# Altium schematic sheet pca20018 - Codec.SchDoc
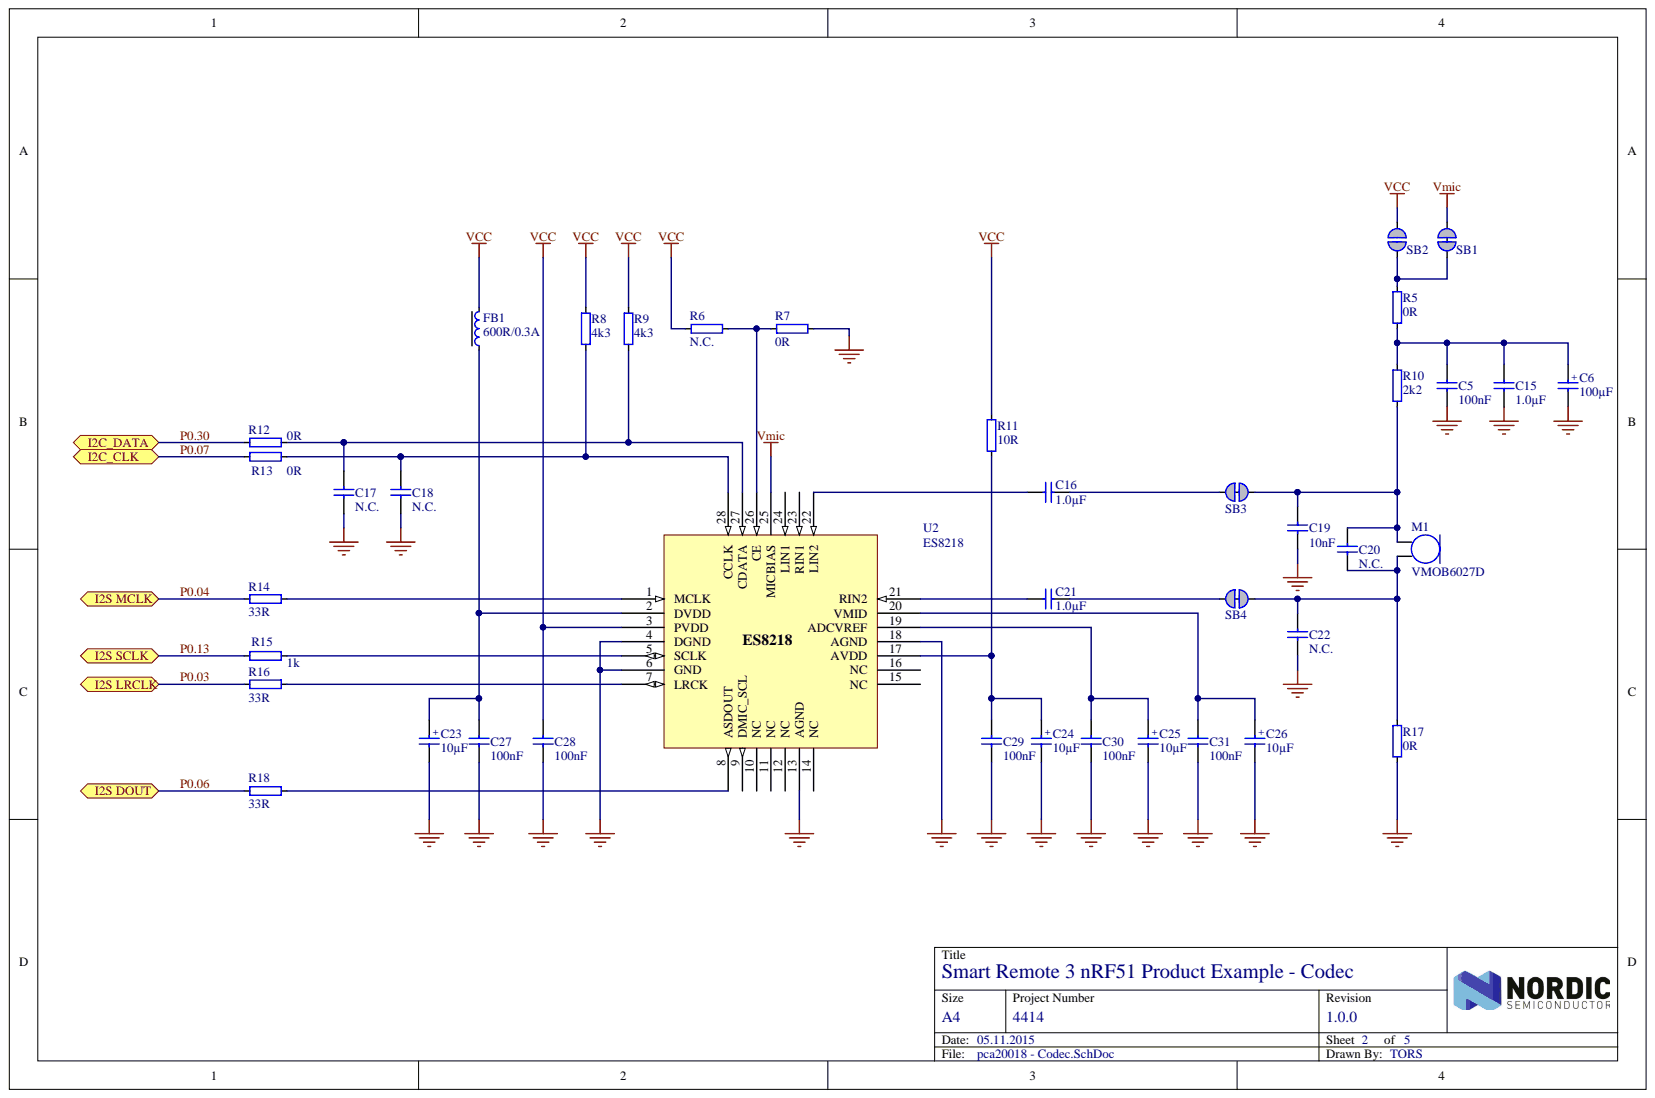
[diagram p0: pca20018 - Codec.SchDoc]

## Parts
C5  100nF  [CAPC1005X04L]
C6  100µF  [CAPMP3528X21N]
C15  1.0µF  [CAPC1005X04L]
C16  1.0µF  [CAPC1005X04L]
C17  N.C.  [CAPC1005X04L]
C18  N.C.  [CAPC1005X04L]
C19  10nF  [CAPC1005X04L]
C20  N.C.  [CAPC1005X04L]
C21  1.0µF  [CAPC1005X04L]
C22  N.C.  [CAPC1005X04L]
C23  10µF  [CAPMP3216X18N]
C24  10µF  [CAPMP3216X18N]
C25  10µF  [CAPMP3216X18N]
C26  10µF  [CAPMP3216X18N]
C27  100nF  [CAPC1005X04L]
C28  100nF  [CAPC1005X04L]
C29  100nF  [CAPC1005X04L]
C30  100nF  [CAPC1005X04L]
C31  100nF  [CAPC1005X04L]
FB1  600R/0.3A  [INDC1005X04L]
M1  VMOB6027D  [MIC_VMOB6027D]
R5  0R  [RESC1005X04L]
R6  N.C.  [RESC1005X04L]
R7  0R  [RESC1005X04L]
R8  4k3  [RESC1005X04L]
R9  4k3  [RESC1005X04L]
R10  2k2  [RESC1005X04L]
R11  10R  [RESC1005X04L]
R12  0R  [RESC1005X04L]
R13  0R  [RESC1005X04L]
R14  33R  [RESC1005X04L]
R15  1k  [RESC1005X04L]
R16  33R  [RESC1005X04L]
R17  0R  [RESC1005X04L]
R18  33R  [RESC1005X04L]
SB1  Solderbridge  [Solderbridge_Shorted]  (value not drawn)
SB2  Solderbridge  [Solderbridge]  (value not drawn)  (no BOM)
SB3  Solderbridge  [Solderbridge_Shorted]  (value not drawn)
SB4  Solderbridge  [Solderbridge_Shorted]  (value not drawn)
U2  ES8218  [QFN45P400X400X90_HS-29N]

## Ports
I2C_CLK, I2C_DATA, I2S DOUT, I2S LRCLK, I2S MCLK, I2S SCLK

## Nets
GND: C5.1 C6.2 C15.1 C17.1 C18.1 C19.1 C22.1 C23.2 C24.2 C25.2 C26.2 C27.1 C28.1 C29.1 C30.1 C31.1 R7.1 R17.1 U2.4(DGND) U2.6(GND) U2.13(AGND) U2.18(AGND) U2.29
VCC: C28.2 FB1.2 R6.2 R8.2 R9.2 R11.2 SB2.1 U2.3(PVDD)
VMIC: SB1.1 U2.25(MICBIAS)
P0.03: R16.1 ; port I2S LRCLK
P0.04: R14.1 ; port I2S MCLK
P0.06: R18.1 ; port I2S DOUT
P0.07: R13.1 ; port I2C_CLK
P0.13: R15.2 ; port I2S SCLK
P0.30: R12.1 ; port I2C_DATA
NetC5_2: C5.2 C6.1 C15.2 R5.1 R10.2
NetC16_1: C16.1 SB3.1
NetC16_2: C16.2 U2.22(LIN2)
NetC17_2: C17.2 R9.1 R12.2 U2.27(CDATA)
NetC18_2: C18.2 R8.1 R13.2 U2.28(CCLK)
NetC19_2: C19.2 C20.2 M1.1 R10.1 SB3.2
NetC20_1: C20.1 C22.2 M1.2 R17.2 SB4.2
NetC21_1: C21.1 SB4.1
NetC21_2: C21.2 U2.21(RIN2)
NetC23_1: C23.1 C27.2 FB1.1 U2.2(DVDD)
NetC24_1: C24.1 C29.2 R11.1 U2.17(AVDD)
NetC25_1: C25.1 C30.2 U2.19(ADCVREF)
NetC26_1: C26.1 C31.2 U2.20(VMID)
NetR5_2: R5.2 SB1.2 SB2.2
NetR6_1: R6.1 R7.2 U2.26(CE)
NetR14_2: R14.2 U2.1(MCLK)
NetR15_1: R15.1 U2.5(SCLK)
NetR16_2: R16.2 U2.7(LRCK)
NetR18_2: R18.2 U2.8(ASDOUT)

Not connected: U2 9(DMIC_SCL) 10(NC) 11(NC) 12(NC) 14(NC) 15(NC) 16(NC) 23(RIN1) 24(LIN1)

## Library data of the parts
C5 C27-C31 = C0402-100n: Capacitor, X7R, ±10%; Artikkelnr Noca: 20039200; Artikkelnr Simpro: N.A.; Database Table Name: Capacitors$; Note: 16V; Component Kind: Standard; Footprint Doc: 0402; LatestRevisionDate: 2009-11-23; Library Name: Nordic Passive DB.DbLib; Part Number: C0402-100n; Pin Count: 2; Published: 2009-05-08; Value: 100nF; Publisher: Nordic Semiconductor
C6 = C3528-100µF: Capacitor, Tantalum, ±10%; Manufacturer: Vishay Sprague; Manufacturer Part Number: TR3B107K6R3C0500; Artikkelnr Noca: N.A.; Database Table Name: Capacitors$; Note: 6.3V; Artikkelnr Simpro: N.A.; Component Kind: Standard; Datasheet: http://www.farnell.com/datasheets/438325.pdf; Footprint Doc: 3528; LatestRevisionDate: 2013-06-05; Library Name: Nordic Passive DB.DbLib; Part Number: C3528-100µF; Pin Count: 2; Published: 2010-10-26; Supplier: Farnell; Supplier part number: 175-4047; Value: 100µF; Publisher: Nordic Semiconductor
C15 C16 C21 = C0402-1µ0: Capacitor, X5R, ±5%; Artikkelnr Noca: 20039235; Artikkelnr Simpro: N.A.; Database Table Name: Capacitors$; Note: 6.3V; Component Kind: Standard; Footprint Doc: 0402; LatestRevisionDate: 2009-11-23; Library Name: Nordic Passive DB.DbLib; Part Number: C0402-1µ0; Pin Count: 2; Published: 2009-05-08; Value: 1.0µF; Publisher: Nordic Semiconductor
C17 C18 C20 C22 = C0402-N.C.: Not mounted; Artikkelnr Noca: N.A.; Database Table Name: Capacitors$; Note: Chip Cap; Artikkelnr Simpro: N.A.; Component Kind: Standard; Footprint Doc: 0402; LatestRevisionDate: 2009-05-08; Library Name: Nordic Passive DB.DbLib; Part Number: C0402-N.C.; Pin Count: 2; Published: 2009-05-08; Value: N.C.; Publisher: Nordic Semiconductor
C19 = C0402-10n: Capacitor, X7R, ±10%; Artikkelnr Noca: 20039166; Artikkelnr Simpro: N.A.; Database Table Name: Capacitors$; Note: 16V; Component Kind: Standard; Footprint Doc: 0402; LatestRevisionDate: 2009-11-23; Library Name: Nordic Passive DB.DbLib; Part Number: C0402-10n; Pin Count: 2; Published: 2009-05-08; Value: 10nF; Publisher: Nordic Semiconductor
C23-C26 = C1206-10µ_Tantal: Capacitor, Tantalum, ±10%; Artikkelnr Noca: N.A.; Database Table Name: Capacitors$; Note: 16V; Artikkelnr Simpro: N.A.; Component Kind: Standard; Footprint Doc: 1206; LatestRevisionDate: 2013-12-17; Library Name: Nordic Passive DB.DbLib; Part Number: C1206-10µ_Tantal; Pin Count: 2; Published: 2011-01-17; Supplier: Digi-Key; Supplier part number: 399-8269-1-ND; Value: 10µF; Publisher: Nordic Semiconductor
FB1 = FB0402-600R@100MHz-300mA: Ferrite Bead, 600 Ohm @ 100MHz, 300mA, 600 mOhm Max; 2nd source part number: 490-1006-1-ND; Manufacturer: Murata; Manufacturer Part Number: BLM15AG601SN1D; Artikkelnr Noca: 33029424; Artikkelnr Simpro: N.A.; Database Table Name: Inductors$; Note: Chip EMIFIL Inductor Type Chip Ferrite Bead; 2nd source Supplier: Digi-Key; Component Kind: Standard; Datasheet: http://www.farnell.com/datasheets/5144.pdf; Footprint Doc: 0402; LatestRevisionDate: 2014-01-10; Library Name: Nordic Passive DB.DbLib; Part Number: FB0402-600R@100MHz-300mA; Pin Count: 2; Published: 2011-09-18; Supplier: Farnell; Supplier part number: 151-5762; Value: 600R/0.3A; Publisher: Nordic Semiconductor
M1 = VMOB6027D-423G-PAHRAC-00-0: Omnidirectional Back Electret Condenser Microphone; Manufacturer: VECO; Artikkelnr Noca: N.A.; Database Table Name: Transducers$; Artikkelnr Simpro: N.A.; Component Kind: Standard; Footprint Doc: MIC_VMOB6027D; LatestRevisionDate: 2014-07-29; Library Name: Nordic Passive DB.DbLib; Manufacturer Part Number: VMOB6027D-423G-PAHRAC-00-0; Part Number: VMOB6027D-423G-PAHRAC-00-0; Pin Count: 2; Published: 2014-07-29; Value: VMOB6027D; Datasheet: C:\Datasheets\VECO\VMOB6027D.pdf; Publisher: Nordic Semiconductor
R5 R7 R12 R13 R17 = R0402-0R: Resistor, ±5%, 0.063W; Artikkelnr Noca: 27049000; Artikkelnr Simpro: N.A.; Database Table Name: Resistors$; Note: Chip Res 5%; Component Kind: Standard; Footprint Doc: 0402; LatestRevisionDate: 2009-11-23; Library Name: Nordic Passive DB.DbLib; Part Number: R0402-0R; Pin Count: 2; Published: 2009-05-08; Supplier: Farnell; Supplier part number: 146-9661; Value: 0R; Publisher: Nordic Semiconductor
R6 = R0402-N.C.: Not mounted; Artikkelnr Noca: N.A.; Database Table Name: Resistors$; Note: Chip Res; Artikkelnr Simpro: N.A.; Component Kind: Standard; Footprint Doc: 0402; LatestRevisionDate: 2009-05-08; Library Name: Nordic Passive DB.DbLib; Part Number: R0402-N.C.; Pin Count: 2; Published: 2009-05-08; Value: N.C.; Publisher: Nordic Semiconductor
R8 R9 = R0402-3k9: Resistor, ±1%, 0.063W; Artikkelnr Simpro: N.A.; Database Table Name: Resistors$; Note: Chip Res 1%; Component Kind: Standard; Footprint Doc: 0402; LatestRevisionDate: 2009-11-23; Library Name: Nordic Passive DB.DbLib; Pin Count: 2; Published: 2009-05-08; Value: 4k3; Publisher: Nordic Semiconductor
R10 = R0402-2k2: Resistor, ±1%, 0.063W; Artikkelnr Noca: 27049081; Artikkelnr Simpro: N.A.; Database Table Name: Resistors$; Note: Chip Res 1%; Component Kind: Standard; Footprint Doc: 0402; LatestRevisionDate: 2009-11-23; Library Name: Nordic Passive DB.DbLib; Part Number: R0402-2k2; Pin Count: 2; Published: 2009-05-08; Supplier: Farnell; Supplier part number: 173-8854; Value: 2k2; Publisher: Nordic Semiconductor
R11 = R0402-10R: Resistor, ±1%, 0.063W; Artikkelnr Noca: 27049025; Artikkelnr Simpro: N.A.; Database Table Name: Resistors$; Note: Chip Res 1%; Component Kind: Standard; Footprint Doc: 0402; LatestRevisionDate: 2009-11-23; Library Name: Nordic Passive DB.DbLib; Part Number: R0402-10R; Pin Count: 2; Published: 2009-05-08; Supplier: Farnell; Supplier part number: 173-8824; Value: 10R; Publisher: Nordic Semiconductor
R14 R16 R18 = R0402-33R: Resistor, ±1%, 0.063W; Artikkelnr Noca: 27049037; Artikkelnr Simpro: N.A.; Database Table Name: Resistors$; Note: Chip Res 1%; Component Kind: Standard; Footprint Doc: 0402; LatestRevisionDate: 2009-11-23; Library Name: Nordic Passive DB.DbLib; Part Number: R0402-33R; Pin Count: 2; Published: 2009-05-08; Supplier: Farnell; Supplier part number: 173-8830; Value: 33R; Publisher: Nordic Semiconductor
R15 = R0402-1k0: Resistor, ±1%, 0.063W; Artikkelnr Noca: 27049073; Artikkelnr Simpro: N.A.; Database Table Name: Resistors$; Note: Chip Res 1%; Component Kind: Standard; Footprint Doc: 0402; LatestRevisionDate: 2009-11-23; Library Name: Nordic Passive DB.DbLib; Part Number: R0402-1k0; Pin Count: 2; Published: 2009-05-08; Supplier: Farnell; Supplier part number: 173-8850; Value: 1k; Publisher: Nordic Semiconductor
SB1 SB3 SB4 = Solderbridge Shorted: Shorted Solderbridge; Artikkelnr Noca: N.A.; Database Table Name: Connectors$; Artikkelnr Simpro: N.A.; Component Kind: Net Tie; Footprint Doc: Solderbridge_Shorted; LatestRevisionDate: 2012-08-07; Library Name: Nordic Passive DB.DbLib; Part Number: Solderbridge Shorted; Pin Count: 2; Published: 2012-08-07; Value: Solderbridge; Publisher: Nordic Semiconductor
SB2 = Solderbridge: Artikkelnr Noca: N.A.; Database Table Name: Connectors$; Artikkelnr Simpro: N.A.; Description: Solderbridge; Footprint Doc: Solderbridge; LatestRevisionDate: 2009-12-14; Library Name: Nordic Passive DB.DbLib; Part Number: Solderbridge; Pin Count: 2; Published: 2009-12-14; Value: Solderbridge; Publisher: Nordic Semiconductor
U2 = ES8218: High performance, low power and low cost audio ADC. It consists of 2-ch ADC, microphone amplifier and auto level control; Manufacturer: Everest Semiconductor; Artikkelnr Noca: N.A.; Database Table Name: Misc IC$; Artikkelnr Simpro: N.A.; Component Kind: Standard; Datasheet: http://projecttools.nordicsemi.no/confluence/download/attachments/10067496/Everest+ES8288+DS.pdf?version=1&modificationDate=1369290839060; Footprint Doc: QFN-28; LatestRevisionDate: 2014-07-10; Library Name: Nordic Active DB.DbLib; Manufacturer Part Number: ES8218; Part Number: ES8218; Pin Count: 29; Published: 2014-07-03; Value: ES8218; Publisher: Nordic Semiconductor
